# Altium schematic sheet PAGE5-CURRENT_MONITOR.SchDoc(PAGE5-CURRENT_MONITOR)
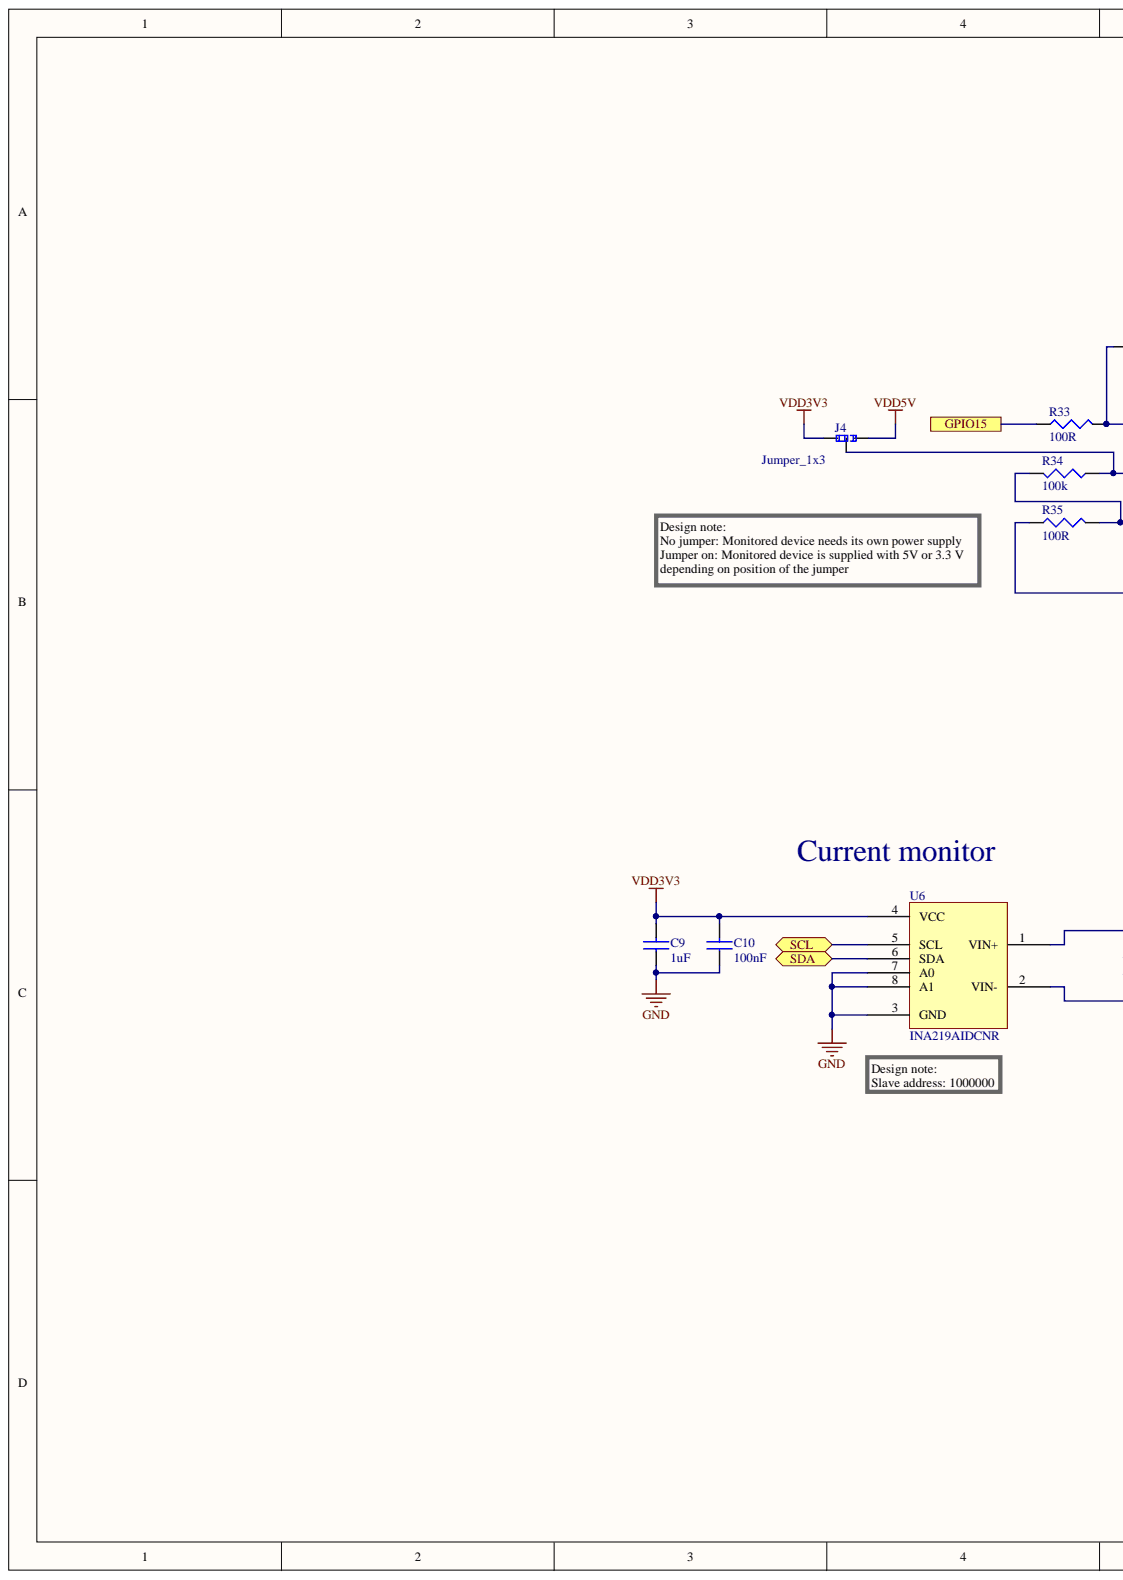
[diagram p0: PAGE5-CURRENT_MONITOR.SchDoc, left]
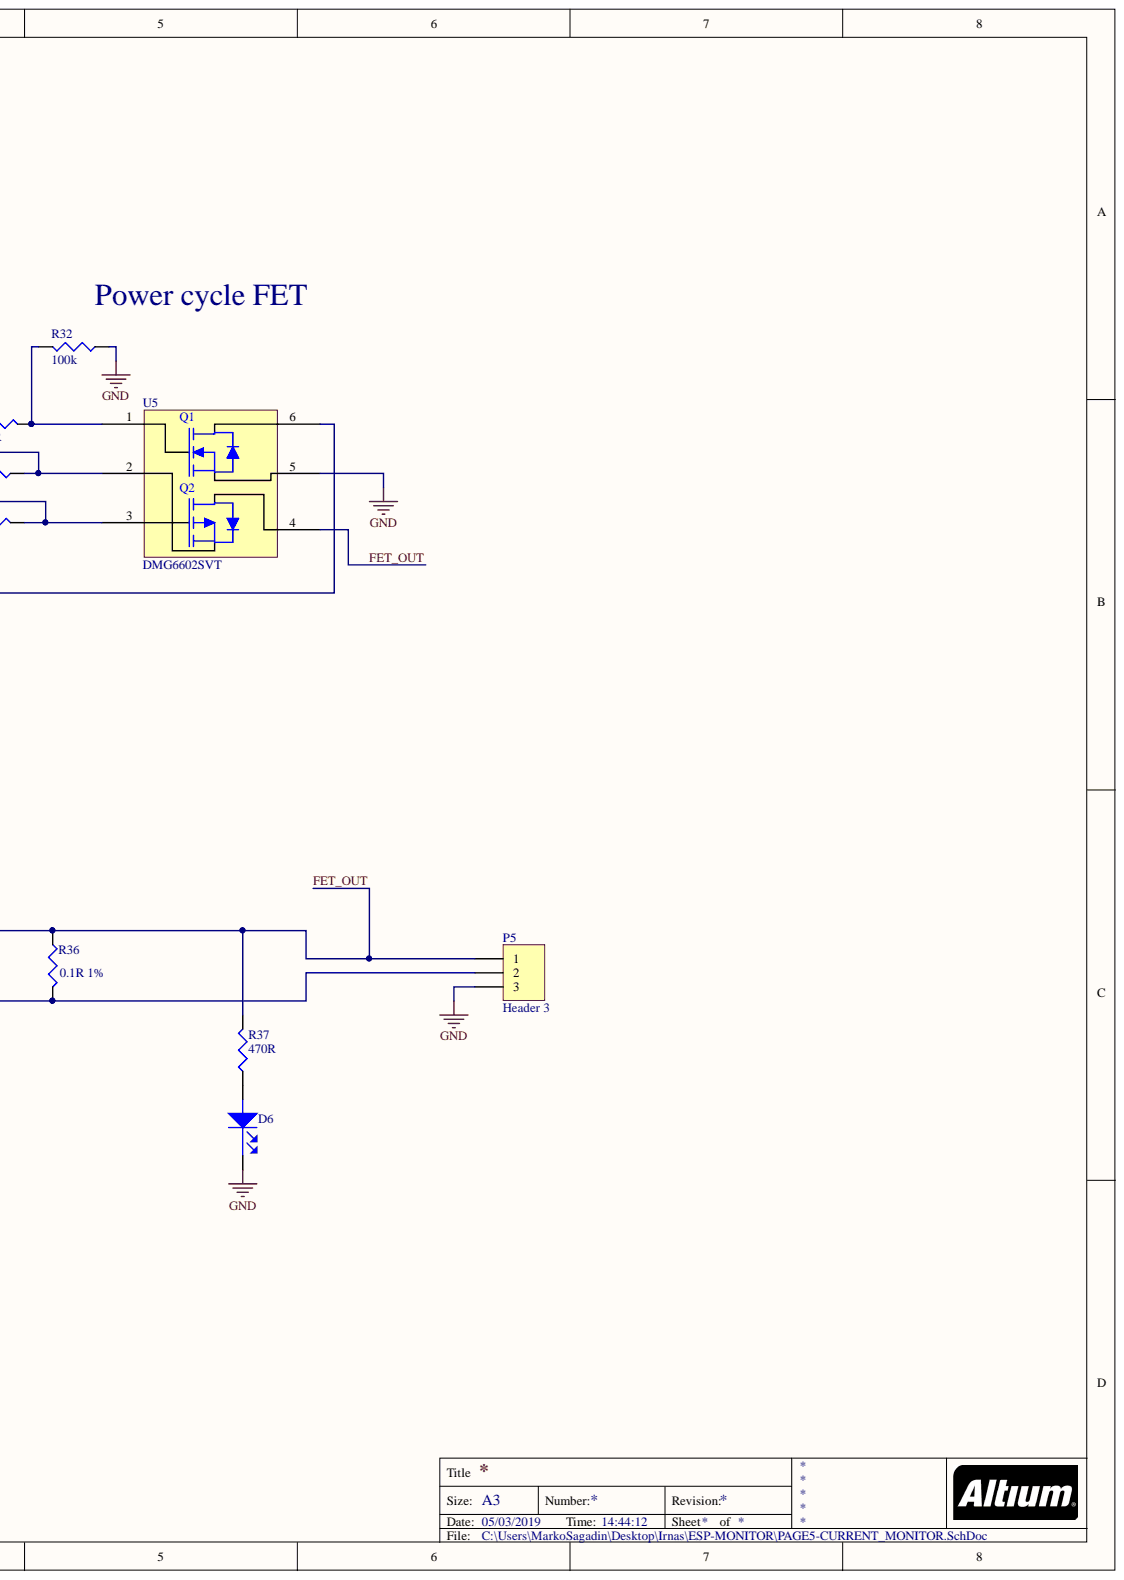
[diagram p1: PAGE5-CURRENT_MONITOR.SchDoc, right]

## Parts
C9  1uF  [CAP0603]
C10  100nF  [CAP0603]
D6  LED RED  [LED0603]  (value not drawn)
J4  Jumper_1x3  [Jumper_1x3_2.54MM]
P5  Header 3  [HDR1X3]
R32  100k  [RES0603]
R33  100R  [RES0603]
R34  100k  [RES0603]
R35  100R  [RES0603]
R36  0.1R 1%  [RES1206]
R37  470R  [RES0603]
U5  DMG6602SVT  [TSOT26]
U6  INA219AIDCNR  [SOT23-8]

## Ports
GPIO15, SCL, SDA

## Nets
GND: C9.1 C10.1 D6.1 P5.3 R32.1 U5.5 U6.3(GND) U6.7(A0) U6.8(A1)
VDD3V3: C9.2 C10.2 J4.1 U6.4(VCC)
VDD5V: J4.3
FET_OUT: P5.1 R36.1 R37.2 U5.4 U6.1(VIN+)
GPIO15: R33.1 ; port GPIO15
SCL: U6.5(SCL) ; port SCL
SDA: U6.6(SDA) ; port SDA
NetD6_2: D6.2 R37.1
NetJ4_2: J4.2 R34.1 U5.2
NetP5_2: P5.2 R36.2 U6.2(VIN-)
NetR32_2: R32.2 R33.2 U5.1
NetR34_2: R34.2 R35.2 U5.3
NetR35_1: R35.1 U5.6

## Library data of the parts
C9 = 1uF: MURATA - GRM188R61H105KAALD - CAP, MLCC, X5R, 1UF, 50V, 0603; Voltage Rating: 50; SVHC: No SVHC (17-Dec-2015); Product Range: GRM Series; Dielectric Characteristic: X5; Ceramic Capacitor Case: 0603 [1608 Metric]; Capacitor Terminals: SMD<!--FANDB--><div class="features-and-benefits-container"><div class="features-and-benefits-description"><span class="features-benefits-value">The Murata GRM series capacitors are Multilayer Ceramic Chip Capacitors (MLCC) used for general purpose applications. These MLCC capacitors are classified into temperature compensating and high dielectric constant types depending on the change in electrostatic capacitance due to change in temperature. The temperature compensating capacitors feature a small rate of change in electrostatic capacitance as the temperature changes and are used for applications such as filters and high frequency circuit matching. The high dielectric constant capacitors use materials with a high dielectric constant and feature a large electrostatic capacitance. Typical applications are power supply decoupling and smoothing circuits.</span></div><div class="features-and-benefits-list"><ul class="features-and-benefits-list-list"><li class="features-and-benefits-list-item">Large capacity and small size in a multilayer structure</li><li class="features-and-benefits-list-item">Capacitance value ranging from 0.1pF to 220?F</li><li class="features-and-benefits-list-item">Tin plated external electrodes, excellent solderability</li><li class="features-and-benefits-list-item">Provides good high frequency characteristics</li><li class="features-and-benefits-list-item">Excellent pulse response</li><li class="features-and-benefits-list-item">High reliability</li><li class="features-and-benefits-list-item">Capacitor size varies from 0.4×0.2mm to 5.7×5.0mm</li><li class="features-and-benefits-list-item">Rated voltage of 2.5VDC to 3.15; Capacitance Tolerance ±: ± 10%; Capacitance: 1; Supplier Part Number 1: 1845736; Supplier 1: Farnell; Stock 1: 62682; RoHS: YES; Pricing 1: 10=0.093, 100=0.062, 500=0.0507, 1000=0.0465, 2000=0.0429, 4000=0.0399, 20000=0.0372, 40000=0.0365 (GBP); Packaging: Cut Tape; Operating Temperature Min: -55; Operating Temperature Max: 85; MSL: -; Manufacturer Part Number: GRM188R61H105KAALD; Manufacturer: MURATA; Library Name: Capacitors.IntLib; ComponentLink1URL: http://www.farnell.com/datasheets/2048611.pdf; ComponentLink1Description: http://www.farnell.com/datasheets/2048611.pdf; Component Kind: Standard; Capacitance Tolerance: ± 10%; Automotive Qualification Standard: -
C10 = 100nF: MURATA - GRM188R71C104KA01J - CAP, MLCC, X7R, 0.1UF, 16V, 0603; Voltage Rating: 16; SVHC: No SVHC (20-Jun-2016; Product Range: GRM Series; Dielectric Characteristic: X7; Ceramic Capacitor Case: 0603 [1608 Metric]; Capacitance Tolerance: ± 10%; Capacitance: 0.1; Supplier Part Number 1: 2688519; Supplier 1: Farnell; Stock 1: 18297; RoHS: YES; Pricing 1: 10=0.0145, 100=0.0126, 500=0.0081, 2500=0.0059, 5000=0.0052, 10000=0.0046, 50000=0.0036, 100000=0.0035 (GBP); Packaging: Cut Tape; Operating Temperature Min: -55; Operating Temperature Max: 125; MSL: MSL 1 - Unlimited; Manufacturer Part Number: GRM188R71C104KA01J; Manufacturer: MURATA; Library Name: Capacitors.IntLib; ComponentLink1URL: http://www.farnell.com/datasheets/2189124.pdf; ComponentLink1Description: http://www.farnell.com/datasheets/2189124.pdf; Component Kind: Standard; Automotive Qualification Standard: -
D6 = LED RED: BROADCOM LIMITED - HSMS-C190 - LED, RED, 10MCD, 626NM; Wavelength Typ: 626; Viewing Angle: 170°; SVHC: No SVHC (17-Dec-2015)<!--FANDB--><div class="features-and-benefits-container"><div class="features-and-benefits-description"><span class="features-benefits-value">The HSMS-C190 is a HER SMD Chip LED with untinted/diffused lens, made of GaP. It is designed in an industry standard package 1.6 x 0.8mm footprint with 0.8mm profile. It is compatible with IR reflow solder processes. The small size and wide viewing angle make this LED prime choices for backlighting applications and front panel illumination especially where space is a premium.</span></div><div class="features-and-benefits-list"><ul class="features-and-benefits-list-list"><li class="features-and-benefits-list-item">Small size</li><li class="features-and-benefits-list-item">Industry standard footprint</li><li class="features-and-benefits-list-item">Compatible with IR solder</li><li class="features-and-benefits-list-item">Diffused optics</li><li class="features-and-benefits-list-item">-40 to 85; Luminous Intensity: 10; Lens Shape: Rectangular; LED Mounting: SMD; Forward Voltage: 2.1; Forward Current If: 20; Supplier Part Number 1: 2497356; Supplier 1: Farnell; Stock 1: 7534; RoHS: YES; Product Range: -; Pricing 1: 1=0.361, 10=0.256, 50=0.235, 100=0.106, 200=0.101 (GBP); Packaging: Cut Tape; MSL: -; Manufacturer Part Number: HSMS-C190; Manufacturer: BROADCOM LIMITED; Library Name: Diodes.IntLib; LED Colour: Red; ComponentLink3URL: http://www.farnell.com/datasheets/2165483.pdf; ComponentLink3Description: http://www.farnell.com/datasheets/2165483.pdf; ComponentLink2URL: http://www.farnell.com/datasheets/1681524.pdf; ComponentLink2Description: http://www.farnell.com/datasheets/1681524.pdf; ComponentLink1URL: http://www.farnell.com/datasheets/313031.pdf; ComponentLink1Description: http://www.farnell.com/datasheets/313031.pdf; Component Kind: Standard; Bulb Size: -; Automotive Qualification Standard: -
P5 = Header 3: Header, 3-Pin; Signal Integrity: Connector; Library Name: Miscellaneous Connectors.IntLib; LatestRevisionNote: Re-released for DXP Platform.; LatestRevisionDate: 17-Jul-2002; Component Kind: Standard; Publisher: Altium Limited
R32 R34 = 100k: MULTICOMP - MCMR06X104 JTL - RES, CERAMIC, 100K, 5%, 0.1W, 0603; Voltage Rating: 75; Temperature Coefficient: ± 100; Resistor Mounting: Surface Mount Device<!--FANDB--><div class="features-and-benefits-container"><div class="features-and-benefits-description"><span class="features-benefits-value">The Multicomp MCMR series resistors are automotive grade, anti sulphured thick film chip resistor. The resistance range includes E24 and E96 decade values from 1ohm to 10Mohm. These resistors are constructed on top of a high grade ceramic body.</span></div><div class="features-and-benefits-list"><ul class="features-and-benefits-list-list"><li class="features-and-benefits-list-item">EIA 0402, 0603, 0805, 1206 chip sizes available</li><li class="features-and-benefits-list-item">High reliability and stability</li><li class="features-and-benefits-list-item">Automotive grade AEC-Q200 compliant</li><li class="features-and-benefits-list-item">Complaint with (IEC 60068-1) 55/155/56 climatic category</li><li class="features-and-benefits-list-item">Maximum operation voltage of 50V, 75V, 150V and 200V</li><li class="features-and-benefits-list-item">Power rating of 62.5mW, 100mW, 125mW and 250mW</li><li class="features-and-benefits-list-item">Available in ±1% and ±5% tolerance</li><li class="features-and-benefits-list-item">Temperature coefficient (TCR) of +200ppm/°C, +100ppm/°C and -200ppm/°C to +400ppm/°C</li><li class="features-and-benefits-list-item">Operating temperature range -55°C to 155; Resistor Case Style: 0603 [1608 Metric]; Resistance: 100; Product Range: MCMR Series; Supplier Part Number 1: 2073357; Supplier 1: Farnell; Stock 1: 187; RoHS: Y-EX; Resistor Element Material: Ceramic; Resistance Tolerance: ± 5%; Pricing 1: 10=0.0109, 100=0.0085, 500=0.006, 1000=0.0048, 2500=0.004, 5000=0.003, 25000=0.002, 50000=0.0016 (GBP); Power Rating: 100; Packaging: Cut Tape; Manufacturer Part Number: MCMR06X104 JTL; Manufacturer: MULTICOMP; Library Name: Resistors.IntLib; ComponentLink1URL: http://www.farnell.com/datasheets/1716707.pdf; ComponentLink1Description: http://www.farnell.com/datasheets/1716707.pdf; Component Kind: Standard; Automotive Qualification Standard: -
R33 R35 = 100R: MULTICOMP - MC0063W06031100R - RES, THICK FILM, 100R, 1%, 0.063W, 0603; Voltage Rating V DC: 50V<!--FANDB--><div class="features-and-benefits-container"><div class="features-and-benefits-description"><span class="features-benefits-value">The Multicomp MC series current sense resistors are thick film chip resistors. The resistance range includes E96 and E24 decade values from 1ohm to 10Mohm.</span></div><div class="features-and-benefits-list"><ul class="features-and-benefits-list-list"><li class="features-and-benefits-list-item">EIA 0402, 0603, 0805, 1206 chip sizes available</li><li class="features-and-benefits-list-item">Small size and low weight</li><li class="features-and-benefits-list-item">Suitable for both wave and reflow soldering</li><li class="features-and-benefits-list-item">Reduction of assembly costs</li><li class="features-and-benefits-list-item">Maximum working voltage of 50V(0402, 0603), 150V(0805), 200V(1206)</li><li class="features-and-benefits-list-item">Available in ±1%, ±2% and ±5% tolerance</li><li class="features-and-benefits-list-item">Power rating of 62.5mW, 100mW and 125mW</li><li class="features-and-benefits-list-item">±400ppm/°C(1ohm to 10ohm), ±200ppm/°C(11ohm to 100ohm), ±100; Voltage Rating: 50; Temperature Coefficient: ± 200; Temperature Coeff. -: 200; SVHC: No SVHC (12-Jan-2017); Resistor Mounting: Surface Mount Device; Resistor Case Style: 0603 [1608 Metric]; Resistance: 100; Reel Quantity: 5000; Product Range: MC Series; Power Rating: 63; No. of Pins: 2; External Width: 0.8; External Length / Height: 1.6; External Depth: 0.45; Tolerance -: 1%; Tolerance +: 1%; Temperature Coeff. +: 200; Tape Width: 8; Supplier Part Number 1: 9330364; Supplier 1: Farnell; Stock 1: 78152; RoHS: Y-EX; Resistor Element Material: Thick Film; Resistance Tolerance: ± 1%; Pricing 1: 10=0.0011, 100=0.001, 500=0.0009, 1000=0.0008, 2500=0.0007 (GBP); Packaging: Cut Tape; Operating Temperature Range: -55°C to +155; Operating Temperature Min: -55; Operating Temperature Max: 155; Manufacturer Part Number: MC0063W06031100R; Manufacturer: MULTICOMP; Library Name: Resistors.IntLib; ComponentLink3URL: http://www.farnell.com/datasheets/2289252.pdf; ComponentLink3Description: http://www.farnell.com/datasheets/2289252.pdf; ComponentLink2URL: http://www.farnell.com/cad/1561149.zip; ComponentLink2Description: http://www.farnell.com/cad/1561149.zip; ComponentLink1URL: http://www.farnell.com/datasheets/1788326.pdf; ComponentLink1Description: http://www.farnell.com/datasheets/1788326.pdf; Component Kind: Standard; Automotive Qualification Standard: -
R36 = 0.1R 1% 1206: TE CONNECTIVITY - TL2BR10FTE - RESISTOR, METAL , R10, 0.25W, 1%, 1206; Temperature Coefficient: ± 75; Resistor Element Material: Metal Foil; Resistor Case Style: 1206 [3216 Metric]; Resistance: 0.1; Product Range: TL Series; Power Rating: 250; Automotive Qualification Standard: -<!--FANDB--><div class="features-and-benefits-container"></div>; Supplier Part Number 1: 2330389; Supplier 1: Farnell; Stock 1: 1781; RoHS: YES; Resistance Tolerance: ± 1%; Pricing 1: 10=1.07, 100=0.698, 500=0.531, 1000=0.509, 2000=0.487, 4000=0.465, 20000=0.456, 40000=0.446 (GBP); Packaging: Cut Tape; Manufacturer Part Number: TL2BR10FTE; Manufacturer: TE CONNECTIVITY; Library Name: Resistors.IntLib; ComponentLink1URL: http://www.farnell.com/datasheets/580081.pdf; ComponentLink1Description: http://www.farnell.com/datasheets/580081.pdf; Component Kind: Standard
R37 = 470R: MULTICOMP - MCMR06X471 JTL - RES, CERAMIC, 470R, 5%, 0.1W, 0603; Supplier Part Number 1: 2073513; Supplier 1: Farnell; Stock 1: 3786; RoHS: Y-EX; Pricing 1: 10=0.0089, 100=0.0076, 500=0.0063, 1000=0.0049, 2500=0.0033, 5000=0.0024, 25000=0.0016, 50000=0.0013 (GBP); Manufacturer Part Number: MCMR06X471 JTL; Manufacturer: MULTICOMP; Library Name: Resistors.IntLib; ComponentLink1URL: http://www.farnell.com/datasheets/1716707.pdf; ComponentLink1Description: http://www.farnell.com/datasheets/1716707.pdf; Component Kind: Standard
U5 = DMG6602SVT: DIODES INC. - DMG6602SVT - MOSFET, NP CH, , 30V, 3.4, ATSOT23; Transistor Polarity: N and P Channel; Transistor Case Style: TSOT-26; Threshold Voltage Vgs: 1; SVHC: No SVHC (17-Dec-2015); Rds(on) Test Voltage Vgs: 10; Power Dissipation Pd: 1.12; On Resistance Rds(on), P Channel: 0.073; On Resistance Rds(on), N Channel: 0.038; No. of Pins: 6; Module Configuration: Dual; Drain Source Voltage Vds, P Channel: -30; Drain Source Voltage Vds, N Channel: 30; Continuous Drain Current Id, P Channel: -2.8; Continuous Drain Current Id, N Channel: 3.4; Supplier Part Number 1: 2061522; Supplier 1: Farnell; Stock 1: 4881; RoHS: YES; Product Range: -; Pricing 1: 1=0.363, 25=0.339, 100=0.169, 250=0.166, 500=0.108, 1000=0.0809, 2000=0.0793, 6000=0.0777 (GBP); Operating Temperature Range: -55°C to +150°C<!--FANDB--><div class="features-and-benefits-container"><div class="features-and-benefits-description"><span class="features-benefits-value">The DMG6602SVT from Diode Inc is a surface mount complementary pair enhancement mode MOSFET in TSOT-26 package. This MOSFET features low input capacitance, fast switching speed and low input/output leakage, designed to minimize the onstate resistance and maintain superior switching performance, making it ideal for high efficiency power management applications and backlighting.</span></div><div class="features-and-benefits-list"><ul class="features-and-benefits-list-list"><li class="features-and-benefits-list-item">Automotive grade AEC-Q101 qualified</li><li class="features-and-benefits-list-item">UL recognized</li><li class="features-and-benefits-list-item">Drain to source voltage (Vds) of 30V</li><li class="features-and-benefits-list-item">Gate to source voltage (Vgs) of ±20V</li><li class="features-and-benefits-list-item">Continuous drain current of 3.4A</li><li class="features-and-benefits-list-item">Power dissipation (Pd) of 1.27W</li><li class="features-and-benefits-list-item">Operating temperature range -55°C to 150°C</li><li class="features-and-benefits-list-item">Low on state resistance of 38mohm at Vgs of 10; Operating Temperature Min: -55; Operating Temperature Max: 150; On Resistance Rds(on): 0.038; MSL: -; Manufacturer Part Number: DMG6602SVT; Manufacturer: DIODES INC.; Library Name: PiRA-RTC-HAT-PCB.IntLib; Drain Source Voltage Vds: 30; Continuous Drain Current Id: 3.4; ComponentLink1URL: http://www.farnell.com/datasheets/1915929.pdf; ComponentLink1Description: http://www.farnell.com/datasheets/1915929.pdf; Component Kind: Standard; Automotive Qualification Standard: -
U6 = INA219AIDCNR: 26V, Bi-Directional, High-Side, I2C Out Current/Power Monitor 8-SOT-23 -40 to 125; Manufacturer: Texas Instruments; Quiescent Current: 700uA; Output Type: Digital; Output Current per Channel: 10mA; Operating Supply Current: 1mA; Number of Amplifiers: 1; Min Supply Voltage: 3V; Min Input Voltage: 0uV; Max Supply Voltage: 5.5V; Max Supply Current: 5mA; Input Offset Voltage (Vos): 100uV; Common Mode Rejection Ratio: 100dB; Bandwidth: 3.4MHz; Accuracy: 1%; Width: 1.75mm; Weight: 0.001411oz; Supplier Part Number 1: 2295989; Supplier 1: Farnell; Stock 1: 13730; REACH SVHC: No SVHC; Radiation Hardening: No; Pricing 1: 1 = 1,75000, 25 = 1,54000, 50 = 1,39000, 100 = 1,23000, 250 = 1,16000, 500 = 1,08000, 1000 = 0,89900, 2500 = 0,80300 (EUR); Packaging: Tape and Reel; Package Quantity: 3000; Output Current: 10mA; Number of Pins: 8; Nominal Supply Current: 700uA; Min Operating Temperature: -40°C; Max Operating Temperature: 125°C; Max Input Voltage: 26V; Manufacturer Part Number: INA219AIDCNR; Library Name: INA219.IntLib; Length: 3mm; Lead Free: Lead Free; Height: 1.3mm; Features: I²C; Contact Plating: Gold; ComponentLink15URL: http://datasheet.octopart.com/INA219AIDCNR.-Texas-Instruments-datasheet-62277359.pdf?src-supplier=Element14; ComponentLink15Description: http://datasheet.octopart.com/INA219AIDCNR.-Texas-Instruments-datasheet-62277359.pdf?src-supplier=Element14; ComponentLink14URL: http://datasheet.octopart.com/INA219AIDCNR-Texas-Instruments-datasheet-14155040.pdf?src-supplier=IIIC; ComponentLink14Description: http://datasheet.octopart.com/INA219AIDCNR-Texas-Instruments-datasheet-14155040.pdf?src-supplier=IIIC; ComponentLink13URL: http://datasheet.octopart.com/INA219AIDCNR-Texas-Instruments-datasheet-24897021.pdf?src-supplier=Element14; ComponentLink13Description: http://datasheet.octopart.com/INA219AIDCNR-Texas-Instruments-datasheet-24897021.pdf?src-supplier=Element14; ComponentLink12URL: http://datasheet.octopart.com/INA219AIDCNR-Texas-Instruments-datasheet-102489495.pdf?src-supplier=Farnell; ComponentLink12Description: http://datasheet.octopart.com/INA219AIDCNR-Texas-Instruments-datasheet-102489495.pdf?src-supplier=Farnell; ComponentLink11URL: http://datasheet.octopart.com/INA219AIDCNR-Texas-Instruments-datasheet-11538655.pdf?src-supplier=Future+Electronics; ComponentLink11Description: http://datasheet.octopart.com/INA219AIDCNR-Texas-Instruments-datasheet-11538655.pdf?src-supplier=Future+Electronics; ComponentLink10URL: http://sigma.octopart.com/49252122/technical_drawing/Texas-Instruments-INA219AIDCNR.pdf?src-supplier=TI+store; ComponentLink10Description: http://sigma.octopart.com/49252122/technical_drawing/Texas-Instruments-INA219AIDCNR.pdf?src-supplier=TI+store; ComponentLink9URL: http://datasheet.octopart.com/INA219AIDCNR-Texas-Instruments-datasheet-10383171.pdf?src-supplier=TI+store; ComponentLink9Description: http://datasheet.octopart.com/INA219AIDCNR-Texas-Instruments-datasheet-10383171.pdf?src-supplier=TI+store; ComponentLink8URL: http://datasheet.octopart.com/INA219AIDCNR-Texas-Instruments-datasheet-10258484.pdf?src-supplier=TI+store; ComponentLink8Description: http://datasheet.octopart.com/INA219AIDCNR-Texas-Instruments-datasheet-10258484.pdf?src-supplier=TI+store; ComponentLink7URL: https://octopart.com/click/track?ak=2673a2b2&ct=datasheets&sig=0ce0873&at=physicalpart&sid=370&ppid=8600986&hlid=24884001&src-supplier=TI+store; ComponentLink7Description: https://octopart.com/click/track?ak=2673a2b2&ct=datasheets&sig=0ce0873&at=physicalpart&sid=370&ppid=8600986&hlid=24884001&src-supplier=TI+store; ComponentLink6URL: https://www.verical.com/server-webapp/html/getDatasheet?partID=327029&src-supplier=Verical; ComponentLink6Description: https://www.verical.com/server-webapp/html/getDatasheet?partID=327029&src-supplier=Verical; ComponentLink5URL: http://www.ti.com/general/docs/lit/getliterature.tsp?genericPartNumber=INA219&&fileType=pdf&src-supplier=Avnet; ComponentLink5Description: http://www.ti.com/general/docs/lit/getliterature.tsp?genericPartNumber=INA219&&fileType=pdf&src-supplier=Avnet; ComponentLink4URL: http://www.ti.com/general/docs/lit/getliterature.tsp?genericPartNumber=INA219&&fileType=pdf&src-supplier=Element14; ComponentLink4Description: http://www.ti.com/general/docs/lit/getliterature.tsp?genericPartNumber=INA219&&fileType=pdf&src-supplier=Element14; ComponentLink3URL: http://www.farnell.com/datasheets/1725057.pdf?src-supplier=Element14; ComponentLink3Description: http://www.farnell.com/datasheets/1725057.pdf?src-supplier=Element14; ComponentLink2URL: http://www.ti.com/lit/ds/symlink/ina219.pdf?src-supplier=Digi-Key; ComponentLink2Description: http://www.ti.com/lit/ds/symlink/ina219.pdf?src-supplier=Digi-Key; ComponentLink1URL: http://www.ti.com/lit/ds/symlink/ina219.pdf?src-supplier=Farnell; ComponentLink1Description: http://www.ti.com/lit/ds/symlink/ina219.pdf?src-supplier=Farnell; Component Kind: Standard; Case/Package: SOT-23-8
J4 = Jumper_1x3: Description: Jumper_1x3; Component Kind: Standard; Library Name: Vowstar_Connector.SchLib
